# Altium schematic sheet SC8701_EVM_RE0.SchDoc(SC8701_EVM_RE0)
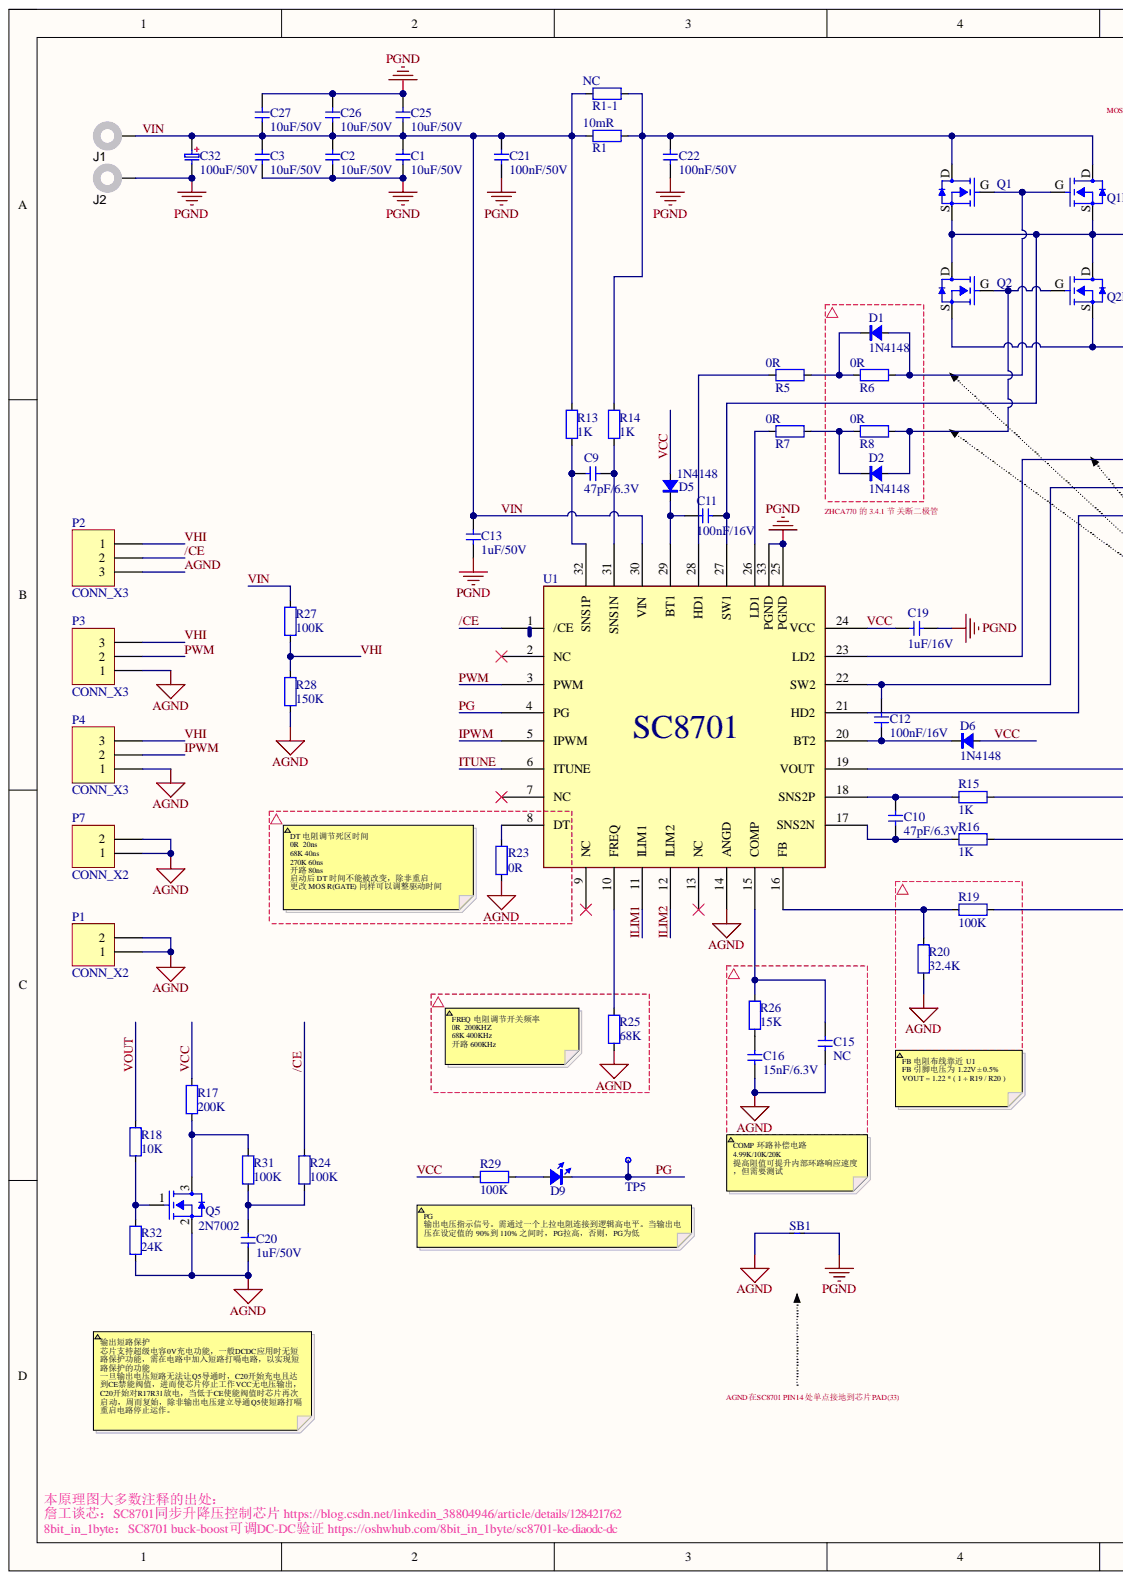
[diagram p0: SC8701_EVM_RE0.SchDoc, left]
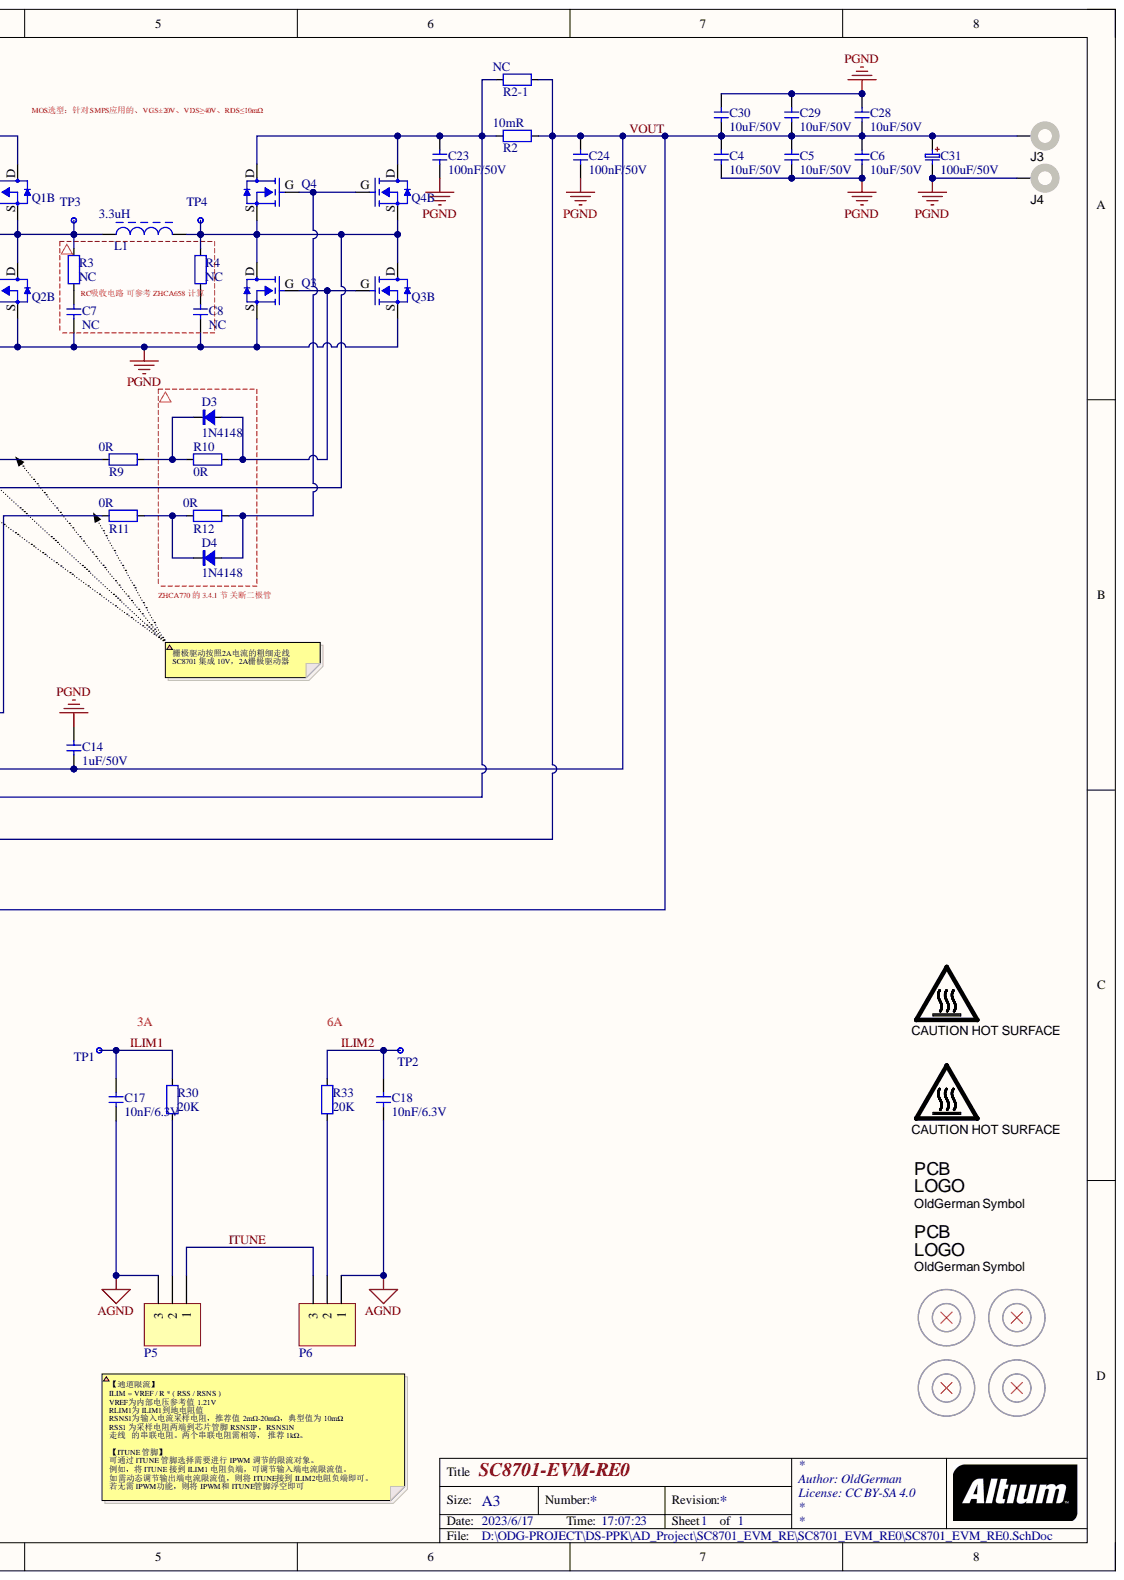
[diagram p1: SC8701_EVM_RE0.SchDoc, right]

## Parts
C1  10uF/50V  [C1206]
C2  10uF/50V  [C1206]
C3  10uF/50V  [C1206]
C4  10uF/50V  [C1206]
C5  10uF/50V  [C1206]
C6  10uF/50V  [C1206]
C7  NC  [C0603]
C8  NC  [C0603]
C9  47pF/6.3V  [C0603]
C10  47pF/6.3V  [C0603]
C11  100nF/16V  [C0603]
C12  100nF/16V  [C0603]
C13  1uF/50V  [C0603]
C14  1uF/50V  [C0603]
C15  NC  [C0603]
C16  15nF/6.3V  [C0603]
C17  10nF/6.3V  [C0603]
C18  10nF/6.3V  [C0603]
C19  1uF/16V  [C0603]
C20  1uF/50V  [C0603]
C21  100nF/50V  [C0603]
C22  100nF/50V  [C0603]
C23  100nF/50V  [C0603]
C24  100nF/50V  [C0603]
C25  10uF/50V  [C1206]
C26  10uF/50V  [C1206]
C27  10uF/50V  [C1206]
C28  10uF/50V  [C1206]
C29  10uF/50V  [C1206]
C30  10uF/50V  [C1206]
C31  100uF/50V  [CAP OS-CON D=10.0 L=10.0 F10]
C32  100uF/50V  [CAP OS-CON D=10.0 L=10.0 F10]
D1  1N4148  [SOD-323]
D2  1N4148  [SOD-323]
D3  1N4148  [SOD-323]
D4  1N4148  [SOD-323]
D5  1N4148  [SOD-323]
D6  1N4148  [SOD-323]
D9  BLUE-LED  [LED0603_BLUE]  (value not drawn)
H1  HOLE  [M3.2 D=8]  (value not drawn)
H2  HOLE  [M3.2 D=8]  (value not drawn)
H3  HOLE  [M3.2 D=8]  (value not drawn)
H4  HOLE  [M3.2 D=8]  (value not drawn)
J1  U6047  [JACK_BANANA_U6047]  (value not drawn)
J2  U6047  [JACK_BANANA_U6047]  (value not drawn)
J3  U6047  [JACK_BANANA_U6047]  (value not drawn)
J4  U6047  [JACK_BANANA_U6047]  (value not drawn)
L1  3.3uH  [INDUCTOR 1350 12.6X13.5X5.0mm]
LOGO1  CAUTION HOT SURFACE  [IEC_HotSurface_Small]  (no BOM)
LOGO2  CAUTION HOT SURFACE  [IEC_HotSurface_Small]  (no BOM)
Logo3  OldGerman Symbol  [ODG_LOGO]  (no BOM)
Logo4  OldGerman Symbol  [ODG_LOGO]  (no BOM)
P1  CONN_X2  [2.54mm_Male_x2]
P2  CONN_X3  [2.54mm_Male_x3]
P3  CONN_X3  [2.54mm_Male_x3]
P4  CONN_X3  [2.54mm_Male_x3]
P5  CONN_X3  [2.54mm_Male_x3]  (value not drawn)
P6  CONN_X3  [2.54mm_Male_x3]  (value not drawn)
P7  CONN_X2  [2.54mm_Male_x2]
Q1  N-MOS_GDS  [SON 3X3 NexFET Q3]  (value not drawn)
Q1B  N-MOS_GDS  [SO8FL ROUND]  (value not drawn)
Q2  N-MOS_GDS  [SON 3X3 NexFET Q3]  (value not drawn)
Q2B  N-MOS_GDS  [SO8FL ROUND]  (value not drawn)
Q3  N-MOS_GDS  [SON 3X3 NexFET Q3]  (value not drawn)
Q3B  N-MOS_GDS  [SO8FL ROUND]  (value not drawn)
Q4  N-MOS_GDS  [SON 3X3 NexFET Q3]  (value not drawn)
Q4B  N-MOS_GDS  [SO8FL ROUND]  (value not drawn)
Q5  2N7002  [INFINEON SOT-23-3]
R1  10mR  [R1206]
R1-1  NC  [R1206]
R2  10mR  [R1206]
R2-1  NC  [R1206]
R3  NC  [R0805]
R4  NC  [R0805]
R5  0R  [R0603]
R6  0R  [R0603]
R7  0R  [R0603]
R8  0R  [R0603]
R9  0R  [R0603]
R10  0R  [R0603]
R11  0R  [R0603]
R12  0R  [R0603]
R13  1K  [R0603]
R14  1K  [R0603]
R15  1K  [R0603]
R16  1K  [R0603]
R17  200K  [R0603]
R18  10K  [R0603]
R19  100K  [R0603]
R20  32.4K  [R0603]
R23  0R  [R0603]
R24  100K  [R0603]
R25  68K  [R0603]
R26  15K  [R0603]
R27  100K  [R0603]
R28  150K  [R0603]
R29  100K  [R0603]
R30  20K  [R0603]
R31  100K  [R0603]
R32  24K  [R0603]
R33  20K  [R0603]
SB1  NetTie_200um  [NetTie_200um]  (value not drawn)
TP1  CONN_X1_DOT  [2.54mm_Male_x1]  (value not drawn)
TP2  CONN_X1_DOT  [2.54mm_Male_x1]  (value not drawn)
TP3  CONN_X1_DOT  [2.54mm_Male_x1]  (value not drawn)
TP4  CONN_X1_DOT  [2.54mm_Male_x1]  (value not drawn)
TP5  CONN_X1_DOT  [2.54mm_Male_x1]  (value not drawn)
U1  SC8701 (A)  [TQFN-32 4X4MM 0.4 VIA]  (value not drawn)

## Nets
PGND: C1.1 C2.1 C3.1 C4.1 C5.1 C6.1 C7.1 C8.1 C13.2 C14.2 C19.2 C21.1 C22.1 C23.1 C24.1 C25.1 C26.1 C27.1 C28.1 C29.1 C30.1 C31.2 C32.2 J2.1 J4.1 Q2.S Q2B.S Q3.S Q3B.S SB1.2 U1.25(PGND) U1.33(PGND)
/CE: P2.2 R24.2 U1.1(/CE)
AGND: C15.1 C16.1 C17.1 C18.1 C20.2 P1.1 P1.2 P2.3 P3.1 P4.1 P5.3 P6.1 P7.1 P7.2 Q5.2 R20.1 R23.1 R25.1 R28.1 R32.1 SB1.1 U1.14(ANGD)
ILIM1: C17.2 R30.2 TP1.1 U1.11(ILIM1)
ILIM2: C18.2 R33.2 TP2.1 U1.12(ILIM2)
IPWM: P4.2 U1.5(IPWM)
ITUNE: P5.1 P6.3 U1.6(ITUNE)
PG: D9.2 TP5.1 U1.4(PG)
PWM: P3.2 U1.3(PWM)
VCC: C19.1 D5.1 D6.1 R17.2 R29.1 U1.24(VCC)
VHI: P2.1 P3.3 P4.3 R27.1 R28.2
VIN: C1.2 C2.2 C3.2 C13.1 C21.2 C25.2 C26.2 C27.2 C32.1 J1.1 R1.1 R1-1.1 R13.2 R27.2 U1.30(VIN)
VOUT: C4.2 C5.2 C6.2 C14.1 C24.2 C28.2 C29.2 C30.2 C31.1 J3.1 R2.2 R2-1.2 R16.1 R18.2 R19.2 U1.19(VOUT)
NetC7_2: C7.2 R3.1
NetC8_2: C8.2 R4.1
NetC9_1: C9.1 R13.1 U1.32(SNS1P)
NetC9_2: C9.2 R14.1 U1.31(SNS1N)
NetC10_1: C10.1 R15.2 U1.18(SNS2P)
NetC10_2: C10.2 R16.2 U1.17(SNS2N)
NetC11_1: C11.1 D5.2 U1.29(BT1)
NetC11_2: C11.2 L1.1 Q1.S Q1B.S Q2.D Q2B.D R3.2 TP3.1 U1.27(SW1)
NetC12_1: C12.1 L1.2 Q3.D Q3B.D Q4.S Q4B.S R4.2 TP4.1 U1.22(SW2)
NetC12_2: C12.2 D6.2 U1.20(BT2)
NetC15_2: C15.2 R26.2 U1.15(COMP)
NetC16_2: C16.2 R26.1
NetC20_1: C20.1 R24.1 R31.1
NetC22_2: C22.2 Q1.D Q1B.D R1.2 R1-1.2 R14.2
NetC23_2: C23.2 Q4.D Q4B.D R2.1 R2-1.1 R15.1
NetD1_1: D1.1 Q1.G Q1B.G R6.2
NetD1_2: D1.2 R5.2 R6.1
NetD2_1: D2.1 Q2.G Q2B.G R8.2
NetD2_2: D2.2 R7.2 R8.1
NetD3_1: D3.1 Q3.G Q3B.G R10.2
NetD3_2: D3.2 R9.2 R10.1
NetD4_1: D4.1 Q4.G Q4B.G R12.2
NetD4_2: D4.2 R11.2 R12.1
NetD9_1: D9.1 R29.2
NetP5_2: P5.2 R30.1
NetP6_2: P6.2 R33.1
NetQ5_1: Q5.1 R18.1 R32.2
NetQ5_3: Q5.3 R17.1 R31.2
NetR5_1: R5.1 U1.28(HD1)
NetR7_1: R7.1 U1.26(LD1)
NetR9_1: R9.1 U1.23(LD2)
NetR11_1: R11.1 U1.21(HD2)
NetR19_1: R19.1 R20.2 U1.16(FB)
NetR23_2: R23.2 U1.8(DT)
NetR25_2: R25.2 U1.10(FREQ)

Not connected: H1 1; H2 1; H3 1; H4 1; U1 2(NC) 7(NC) 9(NC) 13(NC)

## Library data of the parts
C1-C30 = CAP: Capacitor; Component Kind: Standard; Library Name: ODG_AD_Lib.IntLib
C31 C32 = CAP_Polar_Aluminum: Capacitor; Component Kind: Standard; Library Name: ODG_AD_Lib.IntLib
D1-D6 = Diode: 二极管; Component Kind: Standard; Library Name: ODG_AD_Lib.IntLib
D9 = LED: Value: color?; Component Kind: Standard; Description: LED; Library Name: ODG_AD_Lib.IntLib
J1-J4 = JACK_BANANA: Banana Jack; Manufacturer: Keystone; PartNumber: 575-8; CompType: Connector; U/M: EA; Component Kind: Standard; ComponentLink1Description: Datasheet Link; ComponentLink1URL: http://www.keyelco.com/pdfs/M55p95.pdf; LatestRevisionDate: 2015-08-25; MountType: TH; PackageReference: Keystone575-8; RoHS: Y; Supplier 1: Digi-Key; Supplier Part Number 1: 36-575-8-ND; Library Name: ODG_AD_Lib.IntLib; Publisher: Texas Instruments
L1 = Inductor_power: 135 功率电感 额定电流15A; Component Kind: Standard; Library Name: ODG_AD_Lib.IntLib
LOGO1 LOGO2 = IEC_HotSurface: IEC 61010-1 Hot Surface Warning; SymbolCreator: a0412235; LatestRevisionDate: 2014-11-20; Published: 2009-03-03; SymbolVersion: 2011-08-22; Library Name: ODG_AD_Lib.IntLib; Publisher: Texas Instruments
Logo3 Logo4 = OldGeman: SymbolCreator: a0412235; Description: OldGerman Symbol; LatestRevisionDate: 2014-11-20; Library Name: ODG_AD_Lib.IntLib; Published: 2009-03-03; SymbolVersion: 2011-08-22; Publisher: Texas Instruments
Q5 = N-MOS_123: N-MOS; Component Kind: Standard; Library Name: ODG_AD_Lib.IntLib
R1 R1-1 R2 R2-1 R3-R20 R23-R33 = RES: IEC Standard; Component Kind: Standard; Library Name: ODG_AD_Lib.IntLib
SB1 = NetTie 0.2mm: Net Tie; Artikkelnr Noca: N.A.; Pad Count: 2; Component Class: Connectors; Component Kind: Net Tie; ComponentLink1Description: Datasheet; ComponentLink2Description: Supplier link; Footprint Doc: NetTie; LatestRevisionDate: 2019-10-07; Library Name: pca63100.IntLib; Part Number: NetTie 0.2mm; Part number IPS: N.A.; PartId: 100500654; Pin Count: 2; Published: 2019-10-07; Value: NetTie_200um; Publisher: Nordic Semiconductor
U1 = SC8701 (A): 高效率, 同步, 4 管升降压控制器; Component Kind: Standard; Library Name: ODG_AD_Lib.IntLib
H1-H4 = HOLE: Component Kind: Standard; Library Name: ODG_AD_Lib.IntLib
P1 P7 = CONN_X2: Component Kind: Standard; Library Name: ODG_AD_Lib.IntLib
P2-P6 = CONN_X3: Component Kind: Standard; Library Name: ODG_AD_Lib.IntLib
Q1 Q1B Q2 Q2B Q3 Q3B Q4 Q4B = N-MOS_GDS: Component Kind: Standard; Library Name: ODG_AD_Lib.IntLib
TP1-TP5 = CONN_X1_DOT: Component Kind: Standard; Library Name: ODG_AD_Lib.IntLib
